# Altium schematic sheet encode and decode 2.SchDoc("encode and decode 2")
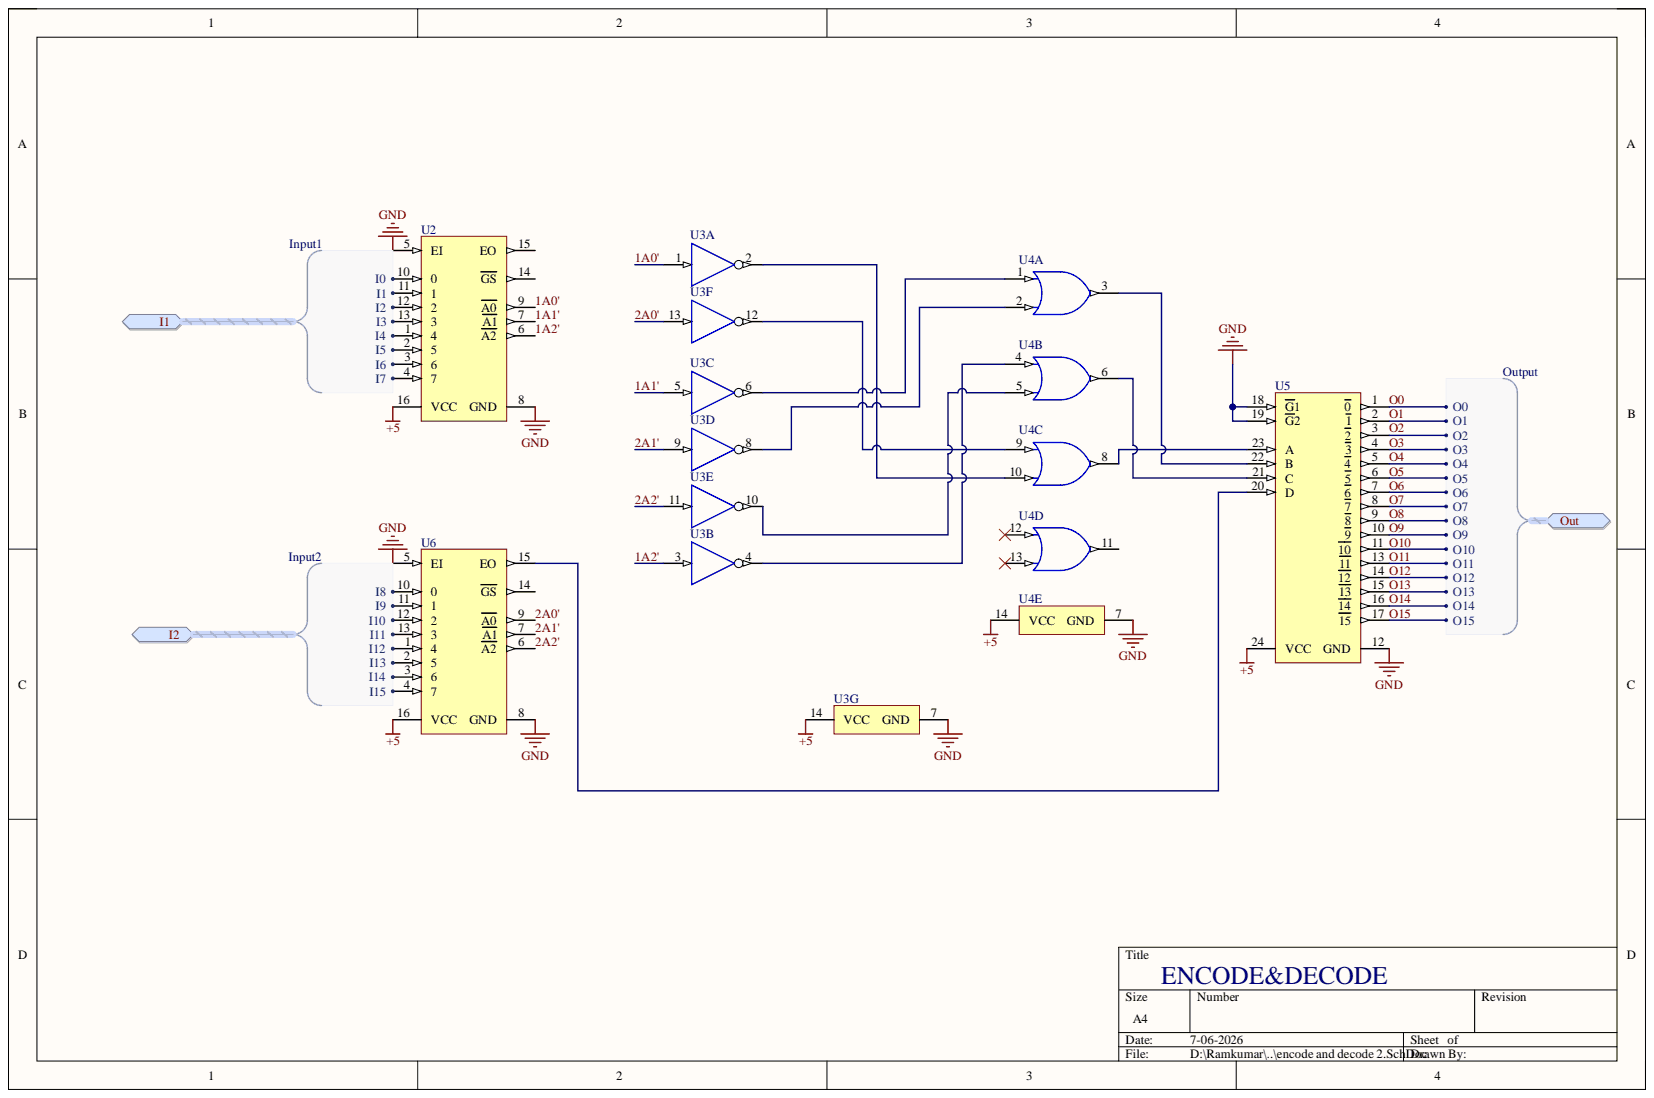
[diagram p0: encode and decode 2.SchDoc]

## Parts
U2  SN74LS148N  [N0016A]  (value not drawn)
U3  SN74HCU04N  [N0014A]  (value not drawn)  (parts A,B,C,D,E,F,G)
U4  SN7432N  [N0014A]  (value not drawn)  (parts A,B,C,D,E)
U5  SN74154N  [N0024A]  (value not drawn)
U6  SN74LS148N  [N0016A]  (value not drawn)

## Ports
I1, I1.I0, I1.I1, I1.I2, I1.I3, I1.I4, I1.I5, I1.I6, I1.I7, I2, I2.I8, I2.I9, I2.I10, I2.I11, I2.I12, I2.I13, I2.I14, I2.I15, Out, Out.O0, Out.O1, Out.O2, Out.O3, Out.O4, Out.O5, Out.O6, Out.O7, Out.O8, Out.O9, Out.O10, Out.O11, Out.O12, Out.O13, Out.O14, Out.O15

## Nets
+5: U2.16(VCC) U3.14(VCC) U4.14(VCC) U5.24(VCC) U6.16(VCC)
GND: U2.5(EI) U2.8(GND) U3.7(GND) U4.7(GND) U5.12(GND) U5.18(G1) U5.19(G2) U6.5(EI) U6.8(GND)
1A0': U2.9(A0) U3.1
1A1': U2.7(A1) U3.5
1A2': U2.6(A2) U3.3
2A0': U3.13 U6.9(A0)
2A1': U3.9 U6.7(A1)
2A2': U3.11 U6.6(A2)
O0: U5.1 ; port Out
O1: U5.2 ; port Out
O2: U5.3 ; port Out
O3: U5.4 ; port Out
O4: U5.5 ; port Out
O5: U5.6 ; port Out
O6: U5.7 ; port Out
O7: U5.8 ; port Out
O8: U5.9 ; port Out
O9: U5.10 ; port Out
O10: U5.11 ; port Out
O11: U5.13 ; port Out
O12: U5.14 ; port Out
O13: U5.15 ; port Out
O14: U5.16 ; port Out
O15: U5.17 ; port Out
NetU2_1: U2.1 ; port I1
NetU2_2: U2.2 ; port I1
NetU2_3: U2.3 ; port I1
NetU2_4: U2.4 ; port I1
NetU2_10: U2.10 ; port I1
NetU2_11: U2.11 ; port I1
NetU2_12: U2.12 ; port I1
NetU2_13: U2.13 ; port I1
NetU3_2: U3.2 U4.10
NetU3_4: U3.4 U4.4
NetU3_6: U3.6 U4.1
NetU3_8: U3.8 U4.2
NetU3_10: U3.10 U4.5
NetU3_12: U3.12 U4.9
NetU4_3: U4.3 U5.22(B)
NetU4_6: U4.6 U5.21(C)
NetU4_8: U4.8 U5.23(A)
NetU5_20: U5.20(D) U6.15(EO)
NetU6_1: U6.1 ; port I2
NetU6_2: U6.2 ; port I2
NetU6_3: U6.3 ; port I2
NetU6_4: U6.4 ; port I2
NetU6_10: U6.10 ; port I2
NetU6_11: U6.11 ; port I2
NetU6_12: U6.12 ; port I2
NetU6_13: U6.13 ; port I2

Not connected: U2 14(GS) 15(EO); U4 11 12 13; U6 14(GS)

## Library data of the parts
U2 U6 = CMP-1633-00673-2: 8-line to 3-line priority encoder, N0016A, TUBE; Manufacturer: Texas Instruments; Bits(#): 8; Channels(#): 1; Configuration: 8：3; F @ Nom Voltage(Max)(Mhz): 35; Function: Encoder/Multiplexer; ICC @ Nom Voltage(Max)(mA): 20; Input Type: TTL; Output Drive (IOL/IOH)(Max)(mA): 8/-0.4; Rating: Catalog; Schmitt Trigger: No; Technology Family: LS; tpd @ Nom Voltage(Max)(ns): 19; VCC(Max)(V): 5.25; VCC(Min)(V): 4.75; Voltage(Nom)(V): 5; Code_JEDEC: MS-001; Component Kind: Standard; DatasheetVersion: SDLS053B; Generic Part Number: SN74LS148; Library Name: Altium Content Vault; Operating Temperature Range(C): 0 to 70; Output Type: TTL; PackageReference: N0016A; Type: Standard
U3 = CMP-1615-00910-2: Hex Inverters, N0014A, TUBE; Manufacturer: Texas Instruments; Bits(#): 6; F @ Nom Voltage(Max)(Mhz): 20; ICC @ Nom Voltage(Max)(mA): 0.02; Input Type: CMOS; Output Drive (IOL/IOH)(Max)(mA): -4/4; Rating: Catalog; Schmitt Trigger: No; Technology Family: HC; VCC(Min)(V): 2; Voltage(Nom)(V): 5; Code_JEDEC: MS-001; Component Kind: Standard; DatasheetVersion: SCLS079E; Generic Part Number: SN74HCU04; Library Name: Altium Content Vault; Operating Temperature Range(C): -40 to 85; Output Type: CMOS; PackageReference: N0014A; tpd @ Nom Voltage(Max)(ns): 20; VCC(Max)(V): 6
U4 = CMP-1573-00140-3: Quad 2-input positive-OR gates, N0014A, TUBE; Generic Part Number: SN7432; Manufacturer: Texas Instruments; Bits(#): 4; F @ Nom Voltage(Max)(Mhz): 100; ICC @ Nom Voltage(Max)(mA): 0.038; Input Type: TTL; Output Drive (IOL/IOH)(Max)(mA): 0.8/-16; Rating: Catalog; tpd @ Nom Voltage(Max)(ns): 22; VCC(Max)(V): 5.25; VCC(Min)(V): 4.75; Voltage(Nom)(V): 5; Code_JEDEC: MS-001; Component Kind: Standard; DatasheetVersion: SDLS100; Library Name: Altium Content Vault; Operating Temperature Range(C): 0 to 70; Output Type: TTL; PackageReference: N0014A; PackageVersion: http://www.ti.com/lit/ml/mpdi002c/mpdi002c.pdf
U5 = CMP-1633-00734-2: 4-Line To 16-Line Decoders/Demultiplexers, N0024A, TUBE; Manufacturer: Texas Instruments; Code_JEDEC: MS-011; Component Kind: Standard; DatasheetVersion: SDLS056; Generic Part Number: SN74154; Library Name: Altium Content Vault; PackageReference: N0024A
